# Altium schematic sheet VL53L3CX.SchDoc(VL53L3CX)
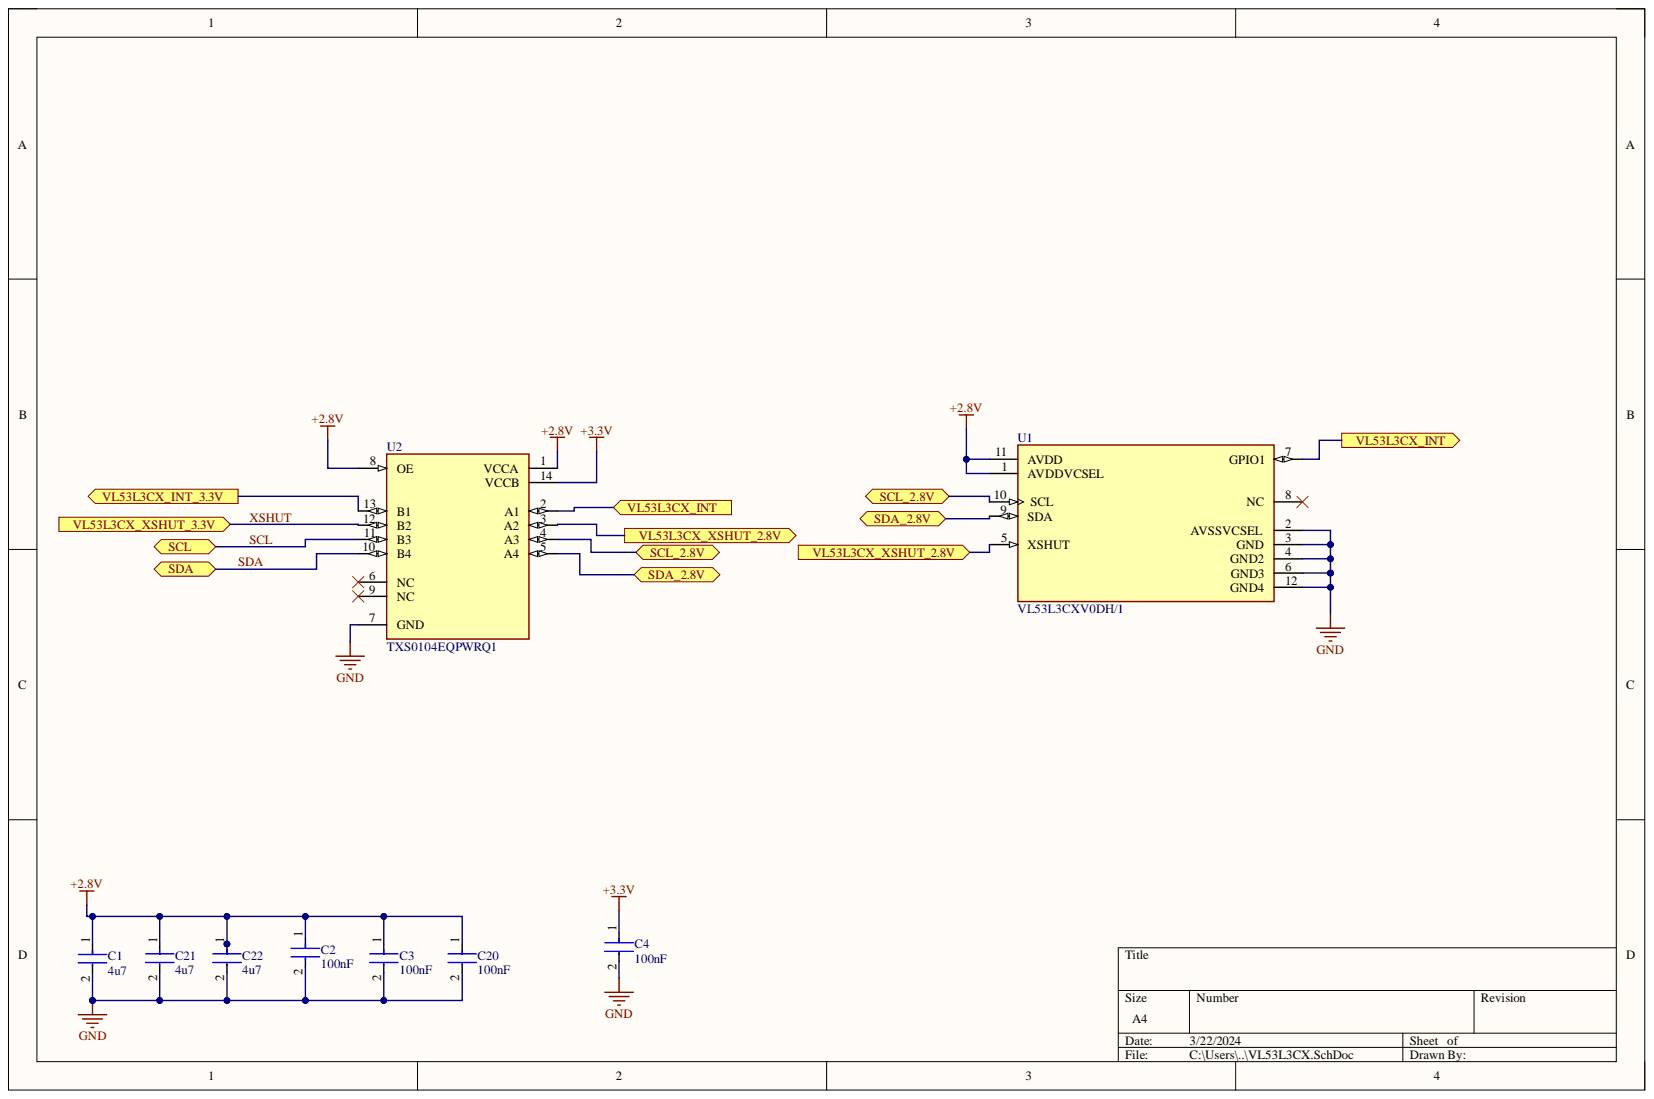
[diagram p0: VL53L3CX.SchDoc]

## Parts
C1  4u7  [FP-GRM21B-0_15-MFG]
C2  100nF  [FP-C1206C-EB-MFG]
C3  100nF  [FP-C1206C-EB-MFG]
C4  100nF  [FP-C1206C-EB-MFG]
C20  100nF  [FP-C1206C-EB-MFG]
C21  4u7  [FP-GRM21B-0_15-MFG]
C22  4u7  [FP-GRM21B-0_15-MFG]
U1  VL53L3CXV0DH/1  [FP-LGA-12-DM00392521-MFG]
U2  TXS0104EQPWRQ1  [FP-PW0014A-IPC_C]

## Ports
SCL, SCL_2.8V, SDA, SDA_2.8V, VL53L3CX_INT, VL53L3CX_INT_3.3V, VL53L3CX_XSHUT_2.8V, VL53L3CX_XSHUT_3.3V

## Nets
+2.8V: C1.1 C2.1 C3.1 C20.1 C21.1 C22.1 U1.1(AVDDVCSEL) U1.11(AVDD) U2.1(VCCA) U2.8(OE)
+3.3V: C4.1 U2.14(VCCB)
GND: C1.2 C2.2 C3.2 C4.2 C20.2 C21.2 C22.2 U1.2(AVSSVCSEL) U1.3(GND) U1.4(GND2) U1.6(GND3) U1.12(GND4) U2.7(GND)
SCL: U2.11(B3) ; port SCL
SCL_2.8V: U1.10(SCL) U2.4(A3) ; port SCL_2.8V
SDA: U2.10(B4) ; port SDA
SDA_2.8V: U1.9(SDA) U2.5(A4) ; port SDA_2.8V
VL53L3CX_INT: U1.7(GPIO1) U2.2(A1) ; port VL53L3CX_INT
VL53L3CX_INT_3.3V: U2.13(B1) ; port VL53L3CX_INT_3.3V
VL53L3CX_XSHUT_2.8V: U1.5(XSHUT) U2.3(A2) ; port VL53L3CX_XSHUT_2.8V
XSHUT: U2.12(B2) ; port VL53L3CX_XSHUT_3.3V

Not connected: U1 8(NC); U2 6(NC) 9(NC)

## Library data of the parts
C1 C21 C22 = CMP-06035-005997-1: Chip Multilayer Ceramic Capacitors for General Purpose, 0805, 4.7uF, X7R, 15%, 10%, 10V; Capacitance: 4.7uF; Case/Package: 0805; Component Kind: Standard; Dielectric: X7R; Length: 2mm; Library Name: Altium Content Vault; Thickness: 1.25mm; Tolerance: 10%; Voltage Rating (DC): 10V; Width: 1.25mm
C2-C4 C20 = CMP-03020-021070-1: CAP CER 0.1UF 16V 10% X7R 1206; Manufacturer: KEMET; Manufacturer Part Number: C1206C104K4RACTU; Automotive Grade: Grade 0; Capacitance: 0.10 μF; Material: Ceramic; Temperature Coefficient: 15%; Tolerance: 0.1; Value: 0.10 μF; Automotive: Yes; Category: Cap; Component Kind: Standard; ComponentLink2Description: Datasheet; ComponentLink2URL: https://content.kemet.com/datasheets/KEM_C1007_X8R_ULTRA_150C_SMD.pdf; Device Class L1: Passive Components; Device Class L2: Capacitors; Device Class L3: Ceramic Capacitors; Digikey Description: CAP CER 0.1UF 16V 10% X7R 1206; Height: 0.88mm; Ipc Land Pattern Name: CAPC320160X78; Lead Free: Yes; Library Name: Altium Content Vault; Mouser Description: Multilayer Ceramic Capacitors MLCC - SMD/SMT 16volts 0.1uF X7R 10%; Octopart: https://octopart.com/c1206c104k4ractu-kemet-12577600; Package: C1206C-EB; RoHS: TRUE; Temperature: -55°C to +150°C; Temperature Characteristic: X7R; Temperature Range High: +150°C; Temperature Range Low: -55°C; Voltage Rating: 16 V
U1 = CMP-12178-000002-1: TIME-OF-FLIGHT PROXIMITY SENSOR; Component Kind: Standard; Library Name: Altium Content Vault
U2 = CMP-04899-000064-1: IC TRNSLTR BIDIRECTIONAL 14TSSOP; Data Rate: 24Mbps; High Level Output Current: -20uA; Logic Function: Translator; Low Level Output Current: 1mA; Max Supply Voltage: 5.5V; Min Supply Voltage: 1.65V; Number of Bits: 4; Number of Circuits: 1; Operating Supply Current: 25uA; Propagation Delay: 4.6ns; Quiescent Current: 14.4uA; Case/Package: TSSOP; Channel Type: Bidirectional; Component Kind: Standard; Contact Plating: Gold; Lead Free: Lead Free; Library Name: Altium Content Vault; Max Operating Temperature: 125°C; Min Operating Temperature: -40°C; Mount: Surface Mount; Number of Channels: 4; Number of Pins: 14; Package Quantity: 2000; Packaging: Tape and Reel; Radiation Hardening: No; RoHS Compliant: Yes; Weight: 0.004949oz
